# Altium schematic sheet SD Card Connector.SchDoc
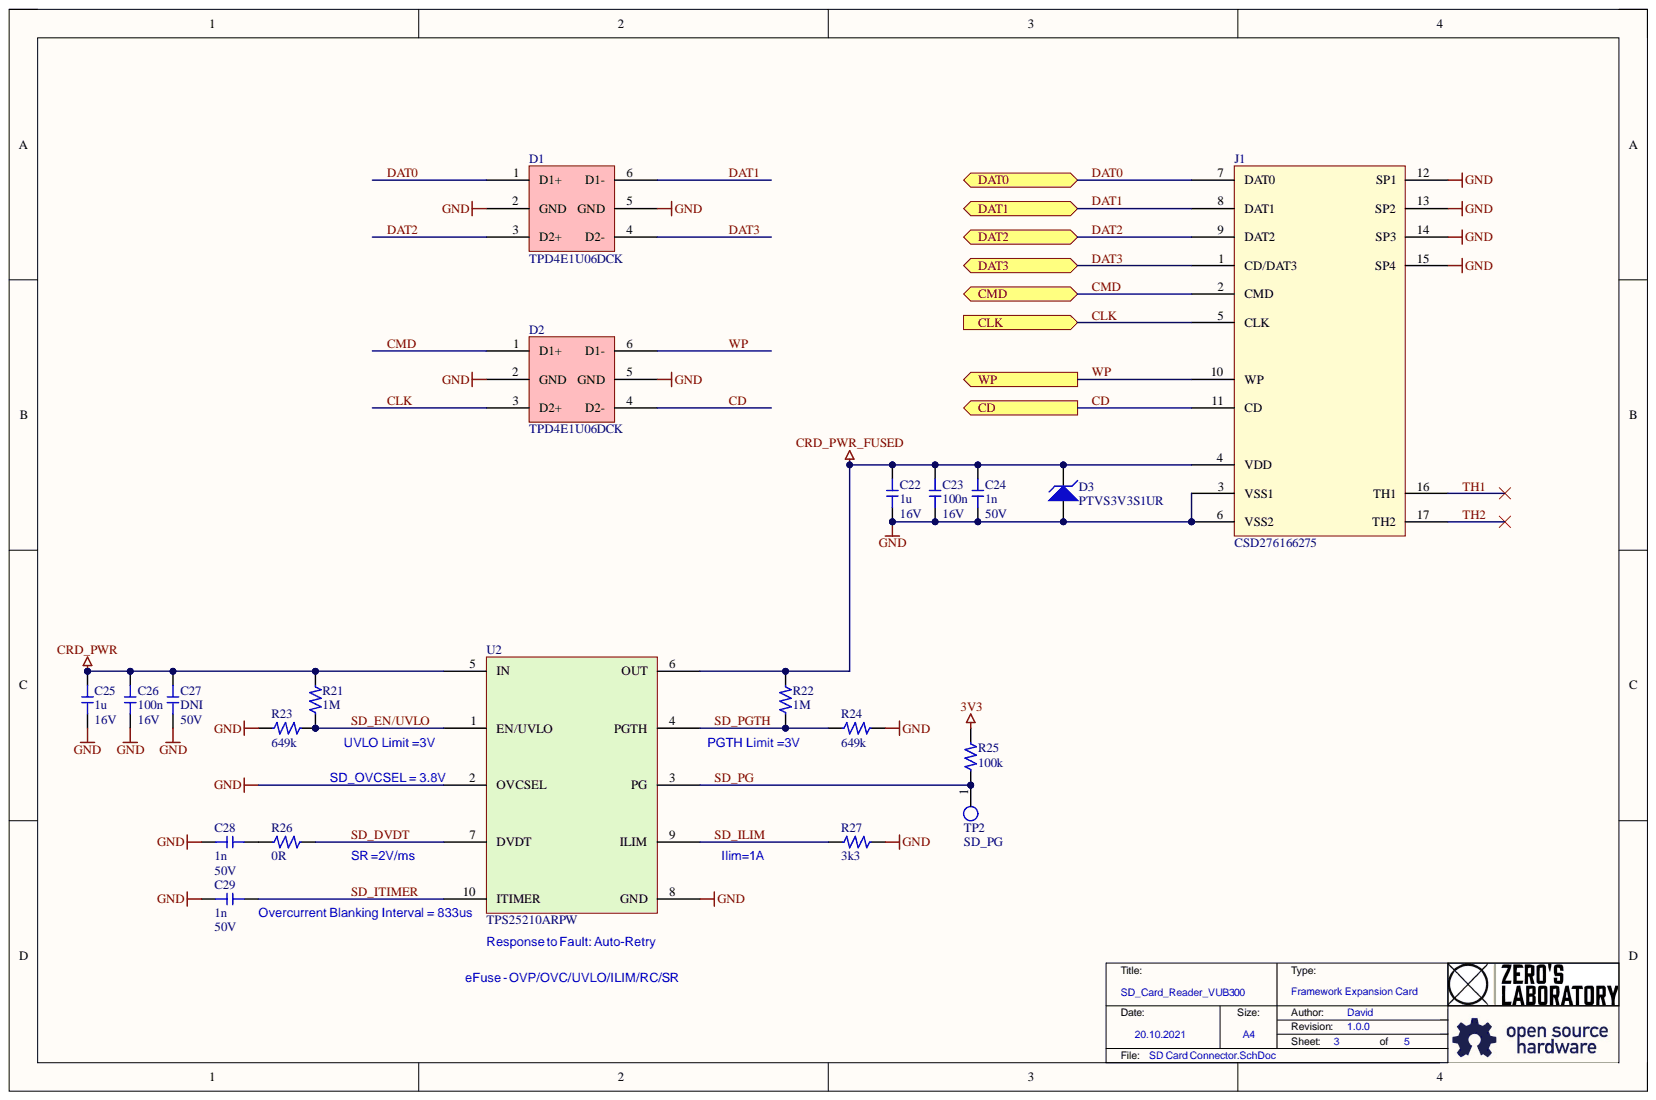
[diagram p0: SD Card Connector.SchDoc]

## Parts
C22  1u  Voltage: 16V  [[0603]_CAPC160X80X100L40]
C23  100n  Voltage: 16V  [[0402]_CAPC100X50X50L25]
C24  1n  Voltage: 50V  [[0402]_CAPC100X50X50L25]
C25  1u  Voltage: 16V  [[0603]_CAPC160X80X100L40]
C26  100n  Voltage: 16V  [[0402]_CAPC100X50X50L25]
C27  DNI  Voltage: 50V  [[0402]_CAPC100X50X50L25]
C28  1n  [[0402]_CAPC100X50X50L25]
C29  1n  Voltage: 50V  [[0402]_CAPC100X50X50L25]
D1  TPD4E1U06DCK  [SC706P65_200X210X95L35X25]
D2  TPD4E1U06DCK  [SC706P65_200X210X95L35X25]
D3  PTVS3V3S1UR
J1  CSD276166275
R21  1M  [[0402]_RESC100X50X35L25]
R22  1M  [[0402]_RESC100X50X35L25]
R23  649k  [[0402]_RESC100X50X35L25]
R24  649k  [[0402]_RESC100X50X35L25]
R25  100k  [[0402]_RESC100X50X35L25]
R26  0R  [[0402]_RESC100X50X35L25]
R27  3k3  [[0402]_RESC100X50X35L25]
TP2  SD_PG  [TP_0.8MM_SMD]  (no BOM)
U2  TPS25210ARPW

## Ports
CD, CLK, CMD, DAT0, DAT1, DAT2, DAT3, WP

## Nets
3V3: R25.2
CRD_PWR: C25.2 C26.2 C27.2 R21.1 U2.5(IN)
CRD_PWR_FUSED: C22.2 C23.2 C24.2 D3.K J1.4(VDD) R22.1 U2.6(OUT)
GND: C22.1 C23.1 C24.1 C25.1 C26.1 C27.1 C28.2 C29.2 D1.2(GND) D1.5(GND) D2.2(GND) D2.5(GND) D3.A J1.3(VSS1) J1.6(VSS2) J1.12(SP1) J1.13(SP2) J1.14(SP3) J1.15(SP4) R23.1 R24.2 R27.2 U2.2(OVCSEL) U2.8(GND)
CD: D2.4(D2-) J1.11(CD) ; port CD
CLK: D2.3(D2+) J1.5(CLK) ; port CLK
CMD: D2.1(D1+) J1.2(CMD) ; port CMD
DAT0: D1.1(D1+) J1.7(DAT0) ; port DAT0
DAT1: D1.6(D1-) J1.8(DAT1) ; port DAT1
DAT2: D1.3(D2+) J1.9(DAT2) ; port DAT2
DAT3: D1.4(D2-) J1.1(CD/DAT3) ; port DAT3
SD_DVDT: R26.2 U2.7(DVDT)
SD_EN/UVLO: R21.2 R23.2 U2.1(EN/UVLO)
SD_ILIM: R27.1 U2.9(ILIM)
SD_ITIMER: C29.1 U2.10(ITIMER)
SD_PG: R25.1 TP2.1 U2.3(PG)
SD_PGTH: R22.2 R24.1 U2.4(PGTH)
TH1: J1.16(TH1)
TH2: J1.17(TH2)
WP: D2.6(D1-) J1.10(WP) ; port WP
NetC28_1: C28.1 R26.1

## Library data of the parts
C22 C25 = Capacitor: Manufacturer: CAL-CHIP ELECTRONICS; MPN: GMC10X7R105K16NT; Capacitance: 1uF; Dielectric: X7R; Tolerance: ±10%; Type: Ceramic; Component Kind: Standard; Description: Capacitor; Library Name: Capacitor.SchLib; Operating Temperature [°C]: -55 to +125; Package: 0603[1608]; Package Type: SMD; Size: 1.60mm x 0.80mm; Value: 1u
C23 C26 = Capacitor: Manufacturer: CAL-CHIP ELECTRONICS; MPN: GMC04X7R104K16NT; Capacitance: 100nF; Dielectric: X7R; Tolerance: ±10%; Type: Ceramic; Component Kind: Standard; Description: Capacitor; Library Name: Capacitor.SchLib; Operating Temperature [°C]: -55 to +125; Package: 0402[1005]; Package Type: SMD; Size: 1.00mm x 0.50mm; Value: 100n
C24 C29 = Capacitor: Manufacturer: CAL-CHIP ELECTRONICS; MPN: GMC04X7R102K50NT; Capacitance: 1nF; Dielectric: X7R; Tolerance: ±10%; Type: Ceramic; Component Kind: Standard; Description: Capacitor; Library Name: Capacitor.SchLib; Operating Temperature [°C]: -55 to +125; Package: 0402[1005]; Package Type: SMD; Size: 1.00mm x 0.50mm; Value: 1n
C27 = Capacitor: Manufacturer: CAL-CHIP ELECTRONICS; MPN: GMC04X7R102K50NT; Capacitance: 1nF; Dielectric: X7R; Tolerance: ±10%; Type: Ceramic; Value: 1n; Component Kind: Standard; Description: Capacitor; Library Name: Capacitor.SchLib; Operating Temperature [°C]: -55 to +125; Package: 0402[1005]; Package Type: SMD; Size: 1.00mm x 0.50mm
C28 = Capacitor: Manufacturer: CAL-CHIP ELECTRONICS; MPN: GMC04X7R102K50NT; Capacitance: 1nF; Dielectric: X7R; Tolerance: ±10%; Type: Ceramic; Voltage: 50V; Component Kind: Standard; Description: Capacitor; Library Name: Capacitor.SchLib; Operating Temperature [°C]: -55 to +125; Package: 0402[1005]; Package Type: SMD; Size: 1.00mm x 0.50mm; Value: 1n
D1 D2 = TPD4E1U06DCKR: Quad-Channel, High-Speed ESD Protection Device; Manufacturer: Texas Instruments; Cl: 0.8pF; Type: ESD Diode; Component Kind: Standard; Library Name: TexasInstruments.SchLib; MPN: TPD4E1U06DCK; MSL: Level-1-260C-UNLIM; Name: TPD4E1U06; Operating temperature [°C]: -40 to +125; Package: SC70; Package Type: SMD; Size: 2.00mm x 1.25mm; Value: TPD4E1U06DCK; Web: https://www.ti.com/product/TPD4E1U06
D3 = TVS Diode: Manufacturer: Nexperia; MPN: PTVS3V3S1UR,115; Ifsm: 50A; Ippm: 43.8A; Name: PTVSxS1UR; Rated Power: 400W; Vbr: 5.6V; Vc: 8V; Vrwm: 3.3V; Component Kind: Standard; Description: TVS Diode; Library Name: Nexperia.SchLib; Operating Temperature [°C]: -55 to +150; Package: SOD123W; Package Type: SMD; Size: 1.70mm x 3.50mm; Value: PTVS3V3S1UR; Web: https://www.nexperia.com/products/automotive-qualified-products-aec-q100-q101/automotive-esd-protection-and-tvs/automotive-transient-voltage-suppressors-tvs/PTVS3V3S1UR.html
J1 = CSD276166275: SDCard connector short type; Manufacturer: Csconn; Pitch: 2.50mm; Component Kind: Standard; Library Name: Csconn.SchLib; MPN: CSD276166275; Name: CSD276166275; Operating temperature [°C]: -25 to +90; Package: SdCard Short; Package Type: SMD; Size: 27.60mm x 16.6.00mm; Type: SD Card Connector; Value: CSD276166275; Web: https://www.csconn.com/UserFiles/upload/file/CSD276166275.pdf
R21 R22 = Resistor: Rated Power: 62.5mW; Resistance: 1MΩ; Tolerance: ±1%; Voltage: 50V; Component Kind: Standard; Description: Resistor; Library Name: Resistor.SchLib; Operating Temperature [°C]: -55 to +155; Package: 0402[1005]; Package Type: SMD; Size: 1.00mm x 0.50mm; Value: 1M
R23 R24 = Resistor: Rated Power: 62.5mW; Resistance: 649kΩ; Tolerance: ±1%; Voltage: 50V; Component Kind: Standard; Description: Resistor; Library Name: Resistor.SchLib; Operating Temperature [°C]: -55 to +155; Package: 0402[1005]; Package Type: SMD; Size: 1.00mm x 0.50mm; Value: 649k
R25 = Resistor: Rated Power: 62.5mW; Resistance: 100kΩ; Tolerance: ±1%; Voltage: 50V; Component Kind: Standard; Description: Resistor; Library Name: Resistor.SchLib; Operating Temperature [°C]: -55 to +155; Package: 0402[1005]; Package Type: SMD; Size: 1.00mm x 0.50mm; Value: 100k
R26 = Resistor: Rated Power: 62.5mW; Resistance: 0Ω; Tolerance: ±1%; Voltage: 50V; Component Kind: Standard; Description: Resistor; Library Name: Resistor.SchLib; Operating Temperature [°C]: -55 to +155; Package: 0402[1005]; Package Type: SMD; Size: 1.00mm x 0.50mm; Value: 0R
R27 = Resistor: Rated Power: 62.5mW; Resistance: 3.3kΩ; Tolerance: ±1%; Voltage: 50V; Component Kind: Standard; Description: Resistor; Library Name: Resistor.SchLib; Operating Temperature [°C]: -55 to +155; Package: 0402[1005]; Package Type: SMD; Size: 1.00mm x 0.50mm; Value: 3k3
TP2 = TestPoint: Test Pad; Library Name: Miscellaneous.SchLib; Value: SD_PG
U2 = TPS25210ARPW: 2.7 - 5.7 V, 4 A, 31-mΩ True Reverse Current Blocking eFuse with Input Reverse Polarity Protection; Manufacturer: Texas Instruments; Pitch: 0.45mm; Component Kind: Standard; Library Name: TexasInstruments.SchLib; MPN: TPS25210ARPW; MSL: Level-2-260C-1 YEAR; Name: TPS2521; Operating temperature [°C]: -40 to +125; Package: QFN-10; Package Type: SMD; Size: 2.00mm x 2.00mm; Type: eFuse; Value: TPS25210ARPW; Web: https://www.ti.com/product/TPS2521
